# Altium schematic sheet 05_POWER.SchDoc(05_POWER)
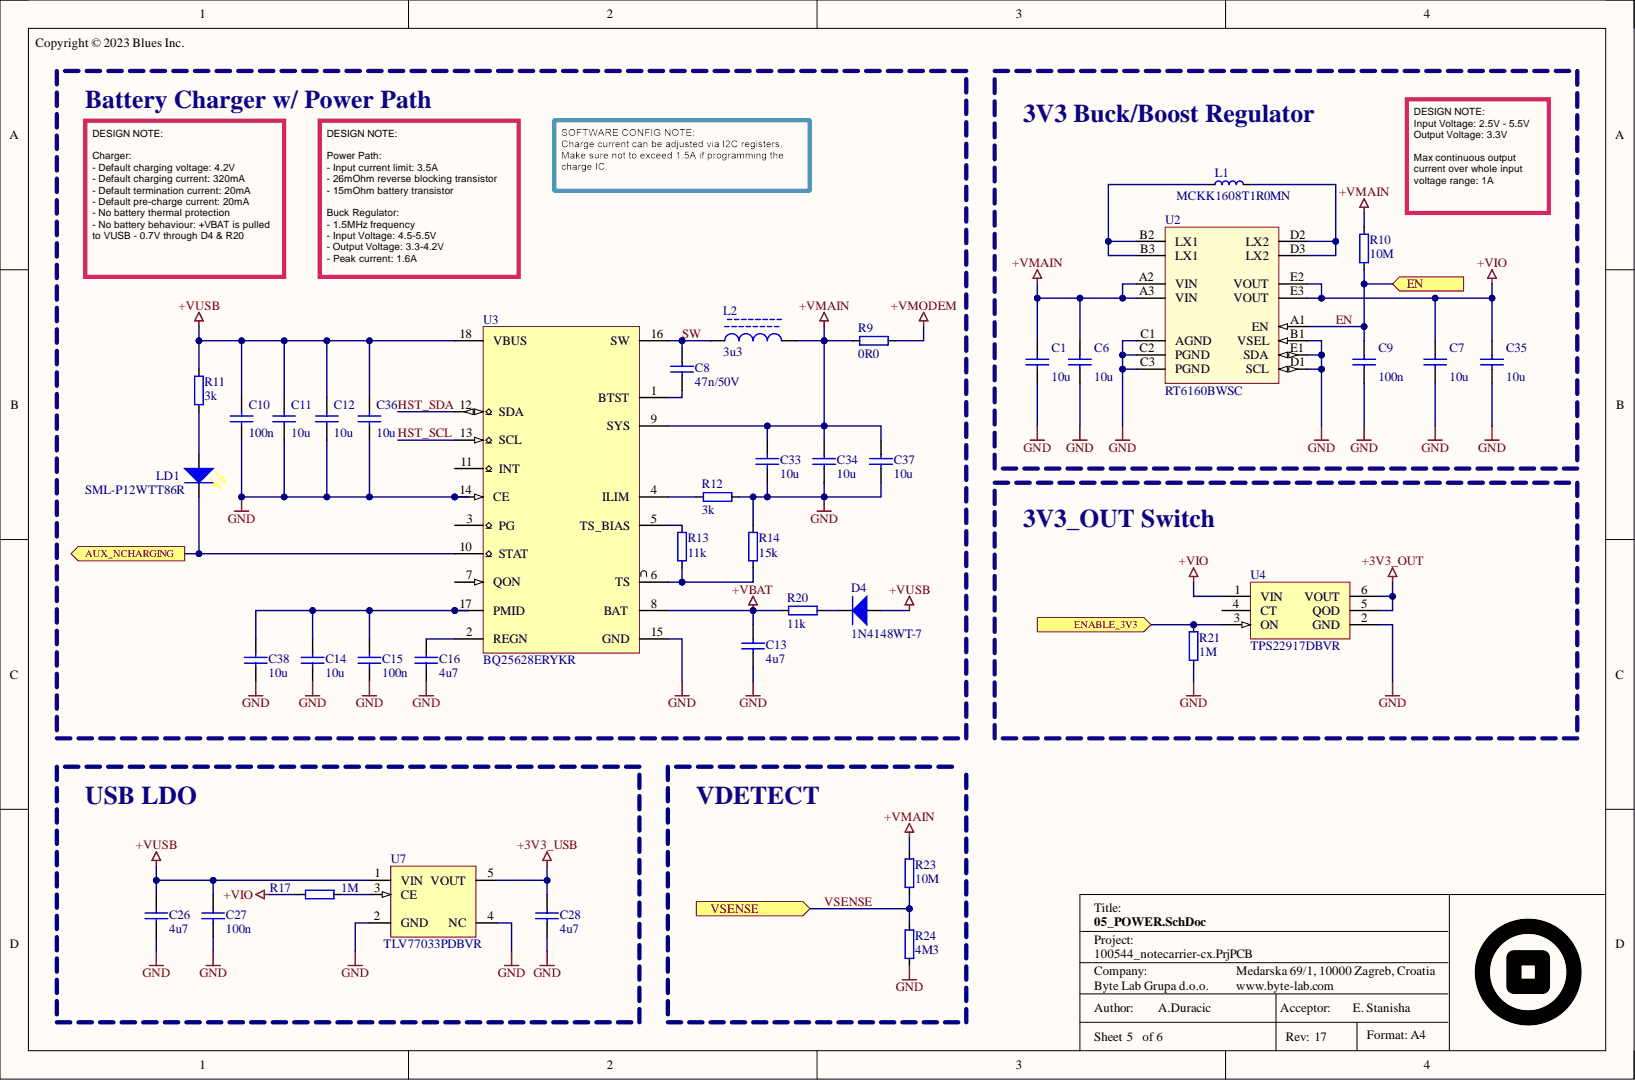
[diagram p0: 05_POWER.SchDoc]

## Parts
C1  10u  [C-0603]
C6  10u  [C-0603]
C7  10u  [C-0603]
C8  47n/50V  [C-0402]
C9  100n  [C-0402]
C10  100n  [C-0402]
C11  10u  [C-0603]
C12  10u  [C-0603]
C13  4u7  [C-0603]
C14  10u  [C-0603]
C15  100n  [C-0402]
C16  4u7  [C-0603]
C26  4u7  [C-0603]
C27  100n  [C-0402]
C28  4u7  [C-0603]
C33  10u  [C-0603]
C34  10u  [C-0603]
C35  10u  [C-0603]
C36  10u  [C-0603]
C37  10u  [C-0603]
C38  10u  [C-0603]
D4  1N4148WT-7  [SOD-523F]
L1  MCKK1608T1R0MN  [L-0603]
L2  3u3  [L-1008]
LD1  SML-P12WTT86R  [LED-0402]
R9  0R0  [R-0603]
R10  10M  [R-0402]
R11  3k  [R-0402]
R12  3k  [R-0402]
R13  11k  [R-0402]
R14  15k  [R-0402]
R17  1M  [R-0402]
R20  11k  [R-0402]
R21  1M  [R-0402]
R23  10M  [R-0402]
R24  4M3  [R-0402]
U2  RT6160BWSC  [BGA15N40P3X5_230x140x60]
U3  BQ25628ERYKR  [QFN40P300X250X80-18N]
U4  TPS22917DBVR  [SOT-23-6 Thin]
U7  TLV77033PDBVR  [SOT-23-5(M5)]

## Ports
AUX_NCHARGING, EN, ENABLE_3V3, VSENSE

## Nets
+3V3_OUT: U4.5(QOD) U4.6(VOUT)
+3V3_USB: C28.1 U7.5(VOUT)
+VBAT: C13.2 R20.1 U3.8(BAT)
+VIO: C7.2 C35.2 R17.2 U2.E2(VOUT) U2.E3(VOUT) U4.1(VIN)
+VMAIN: C1.2 C6.2 C33.1 C34.1 C37.1 L2.2 R9.1 R10.1 R23.2 U2.A2(VIN) U2.A3(VIN) U3.9(SYS)
+VMODEM: R9.2
+VUSB: C10.1 C11.1 C12.1 C26.1 C27.2 C36.1 D4.1 R11.2 U3.18(VBUS) U7.1(VIN)
GND: C1.1 C6.1 C7.1 C9.2 C10.2 C11.2 C12.2 C13.1 C14.1 C15.1 C16.1 C26.2 C27.1 C28.2 C33.2 C34.2 C35.1 C36.2 C37.2 C38.1 R12.1 R14.1 R21.2 R24.1 U2.B1(VSEL) U2.C1(AGND) U2.C2(PGND) U2.C3(PGND) U2.D1(SCL) U2.E1(SDA) U3.14(CE) U3.15(GND) U4.2(GND) U7.2(GND) U7.4(NC)
AUX_NCHARGING: LD1.2 U3.10(STAT) ; port AUX_NCHARGING
EN: C9.1 R10.2 U2.A1(EN) ; port EN
ENABLE_3V3: R21.1 U4.3(ON) ; port ENABLE_3V3
HST_SCL: U3.13(SCL)
HST_SDA: U3.12(SDA)
SW: C8.2 L2.1 U3.16(SW)
VSENSE: R23.1 R24.2 ; port VSENSE
NetC8_1: C8.1 U3.1(BTST)
NetC14_2: C14.2 C15.2 C38.2 U3.17(PMID)
NetC16_2: C16.2 U3.2(REGN)
NetD4_2: D4.2 R20.2
NetL1_1: L1.1 U2.B2(LX1) U2.B3(LX1)
NetL1_2: L1.2 U2.D2(LX2) U2.D3(LX2)
NetLD1_1: LD1.1 R11.1
NetR12_2: R12.2 U3.4(ILIM)
NetR13_1: R13.1 U3.5(TS_BIAS)
NetR13_2: R13.2 R14.2 U3.6(TS)
NetR17_1: R17.1 U7.3(CE)

Not connected: U3 3(PG) 7(QON) 11(INT); U4 4(CT)

## Library data of the parts
C1 C6 C7 C11 C12 C14 C33-C38 = C0603-10u-10V-X5R: Capacitor ceramic 10u ±20% X5R 10V 0603; BL Part Number: 2006-831; Checked_By: Kuljak; Drawn_By: Hamin; Component Kind: Standard; DateModified: 03-05-2023; Library Name: BL_Passive.SchLib; Manufacturer: N/A; Manufacturer Part Number: N/A; Supplier 1: Digi-Key; Supplier Part Number 1: 311-1817-1-ND
C8 = C0402-47n-50V-X7R: Capacitor ceramic 47n 10% X7R 50V 0402; BL Part Number: 2003-965; Checked_By: Hamin; Drawn_By: Hlupic; Component Kind: Standard; DateModified: 08-05-2018; Library Name: BL_Passive.SchLib; Manufacturer: N/A; Manufacturer Part Number: N/A; Supplier 1: Digi-Key; Supplier Part Number 1: 311-3815-2-ND
C9 C10 C27 = C0402-100n-16V-X7R: Capacitor ceramic 100n ±10% X7R 16V 0402; BL Part Number: 2000-908; Checked_By: Dodig; Drawn_By: Puskar; Component Kind: Standard; DateModified: 27-08-2013; Library Name: BL_Passive.SchLib; Manufacturer: N/A; Manufacturer Part Number: N/A; Simulation: CAP; Supplier 1: Digi-Key; Supplier Part Number 1: 490-3261-1-ND
C13 C16 C26 C28 = C0603-4u7-10V-X5R: Capacitor ceramic 4u7 ±10% X5R 10V 0603; BL Part Number: 2003-721; Checked_By: Marsic; Drawn_By: Hamin; Component Kind: Standard; DateModified: 19-06-2023; Library Name: BL_Passive.SchLib; Manufacturer: N/A; Manufacturer Part Number: N/A
C15 = C0402-100n-25V-X5R: Capacitor ceramic 100n ±10% X5R 25V 0402; BL Part Number: 2004-296; Checked_By: Hamin; Drawn_By: PuskarJ; Component Kind: Standard; DateModified: 24-01-2019; Library Name: BL_Passive.SchLib; Manufacturer: N/A; Manufacturer Part Number: N/A; Simulation: CAP; Supplier 1: Digi-Key; Supplier Part Number 1: 1276-1043-1-ND
D4 = 1N4148WT-7: DIODE GEN PURP 80V 125MA SOD523; BL Part Number: 2001-986; Manufacturer: Diodes Inc; Checked_By: Zvonc; Drawn_By: Dodig; Component Kind: Standard; DateModified: 02-07-2015; Library Name: BL_Active.SchLib; Manufacturer Part Number: 1N4148WT-7; Supplier 1: Digi-Key; Supplier Part Number 1: 1N4148WTDICT-ND
L1 = L0603-1u-20 MCKK1608T1R0MN: Inductor 0603 1u ±20% 1.3A; BL Part Number: 2003-989; Manufacturer: Taiyo Yuden; Checked_By: Hlupic; Drawn_By: Fumic; Component Kind: Standard; DateModified: 30-01-2020; Library Name: BL_Passive.SchLib; Manufacturer Part Number: MCKK1608T1R0MN; Supplier 1: Digi-Key; Supplier Part Number 1: 587-5973-1-ND
L2 = L1008-3u3-20 MAMK2520T3R3M: Inductor 3u3 ±20% 1.7A SMD; BL Part Number: 2004-215; Manufacturer: Taiyo Yuden; Checked_By: Hamin; Drawn_By: Hlupic; Component Kind: Standard; DateModified: 30-11-2018; Library Name: BL_Passive.SchLib; Manufacturer Part Number: MAMK2520T3R3M; Supplier 1: Digi-Key; Supplier Part Number 1: 587-3227-1-ND
LD1 = LED_SML-P12WTT86R: LED YELLOW CLEAR 0402 SMD; BL Part Number: 2007-660; Manufacturer: ROHM Semiconductor; Checked_By: Hamin; Component Kind: Standard; DateModified: 19-06-23; Library Name: BL_Active.SchLib; Manufacturer Part Number: SML-P12WTT86R; Note: *; Drawn_By: Hamin
R9 = R0603-0R0: Resistor 0R0 0603; BL Part Number: 2000-723; Checked_By: Puskar; Drawn_By: Dodig; Component Kind: Standard; DateModified: 07-12-2013; Library Name: BL_Passive.SchLib; Manufacturer: N/A; Manufacturer Part Number: N/A; Supplier 1: Digi-Key; Supplier Part Number 1: 311-0.0GRCT-ND
R10 R23 = R0402-10M-1: Resistor 10M ±1% 0402; BL Part Number: 2002-832; Checked_By: Dodig; Drawn_By: Zvonc; Component Kind: Standard; DateModified: 24-05-2016; Library Name: BL_Passive.SchLib; Manufacturer: N/A; Manufacturer Part Number: N/A; Supplier 1: Digi-Key; Supplier Part Number 1: RC0402FR-0710ML
R11 R12 = R0402-3k-1: Resistor 3k ±1% 0402; BL Part Number: 2001-570; Checked_By: Hamin; Drawn_By: Fumic; Component Kind: Standard; DateModified: 06-09-2021; Library Name: BL_Passive.SchLib; Manufacturer: N/A; Manufacturer Part Number: N/A
R13 R20 = R0402-11k-1: Resistor 11k ±1% 0402; BL Part Number: 2004-237; Checked_By: Marsic; Drawn_By: PuskarJ; Component Kind: Standard; DateModified: 18-12-2018; Library Name: BL_Passive.SchLib; Manufacturer: N/A; Manufacturer Part Number: N/A
R14 = R0402-15k-1: Resistor 15k ±1% 0402; BL Part Number: 2001-157; Checked_By: Zvonc; Drawn_By: Puskar; Component Kind: Standard; DateModified: 23-01-2015; Library Name: BL_Passive.SchLib; Manufacturer: N/A; Manufacturer Part Number: N/A; Supplier 1: Digi-Key; Supplier Part Number 1: P15.0KLCT-ND
R17 R21 = R0402-1M-1: Resistor 1M ±1% 0402; BL Part Number: 2000-734; Checked_By: Dodig; Drawn_By: Puskar; Component Kind: Standard; DateModified: 27-08-2013; Library Name: BL_Passive.SchLib; Manufacturer: N/A; Manufacturer Part Number: N/A; Supplier 1: Digi-Key; Supplier Part Number 1: 311-1.00MLRCT-ND
R24 = R0402-4M3-1: Resistor 4M3 ±1% 0402; BL Part Number: 2007-009; Checked_By: Hlupic; Drawn_By: Marsic; Component Kind: Standard; DateModified: 06-12-2022; Library Name: BL_Passive.SchLib; Manufacturer: N/A; Manufacturer Part Number: N/A
U2 = RT6160BWSC: IC REG BUCK BOOST 2V025-5V2 3A; BL Part Number: 2008-326; Manufacturer: Richtek; Checked_By: Marsic; Drawn_By: Drago Anic-Ivicic; Component Kind: Standard; DateModified: 04-03-2024; Library Name: BL_Analog.SchLib; Manufacturer Part Number: RT6160BWSC; Supplier 1: Digi-Key
U3 = BQ25628ERYKR: IC LI-ION CHARGE MGMT 18-QFN; BL Part Number: 2008-206; Manufacturer: Texas Instruments; Checked_By: Kuljak; Drawn_By: Marsic; Component Kind: Standard; DateModified: 17-01-2024; Library Name: BL_Analog.SchLib; Manufacturer Part Number: BQ25628ERYKR; Supplier 1: Digi-Key; Supplier Part Number 1: 296-BQ25628ERYKRCT-ND - Cut Tape (CT)
U4 = TPS22917DBVR: IC LOAD SWITCH SOT23-6; BL Part Number: 2008-396; Manufacturer: Texas Instruments; Checked_By: Hrastic; Drawn_By: Drago Anic-Ivicic; Component Kind: Standard; DateModified: 05-03-2024; Library Name: BL_Digital.SchLib; Manufacturer Part Number: TPS22917DBVR; Supplier 1: Digi-Key; Supplier Part Number 1: 296-50498-1-ND
U7 = TLV77033PDBVR: IC REG LDO 3.3V 50MA SOT23-5; BL Part Number: 2009-790; Manufacturer: Texas Instruments; Checked_By: Duracic; Drawn_By: Marsic; Component Kind: Standard; DateModified: 09-01-2026; Library Name: BL_Analog.SchLib; Manufacturer Part Number: TLV77033PDBVR
